# Altium schematic sheet main.SchDoc(main)
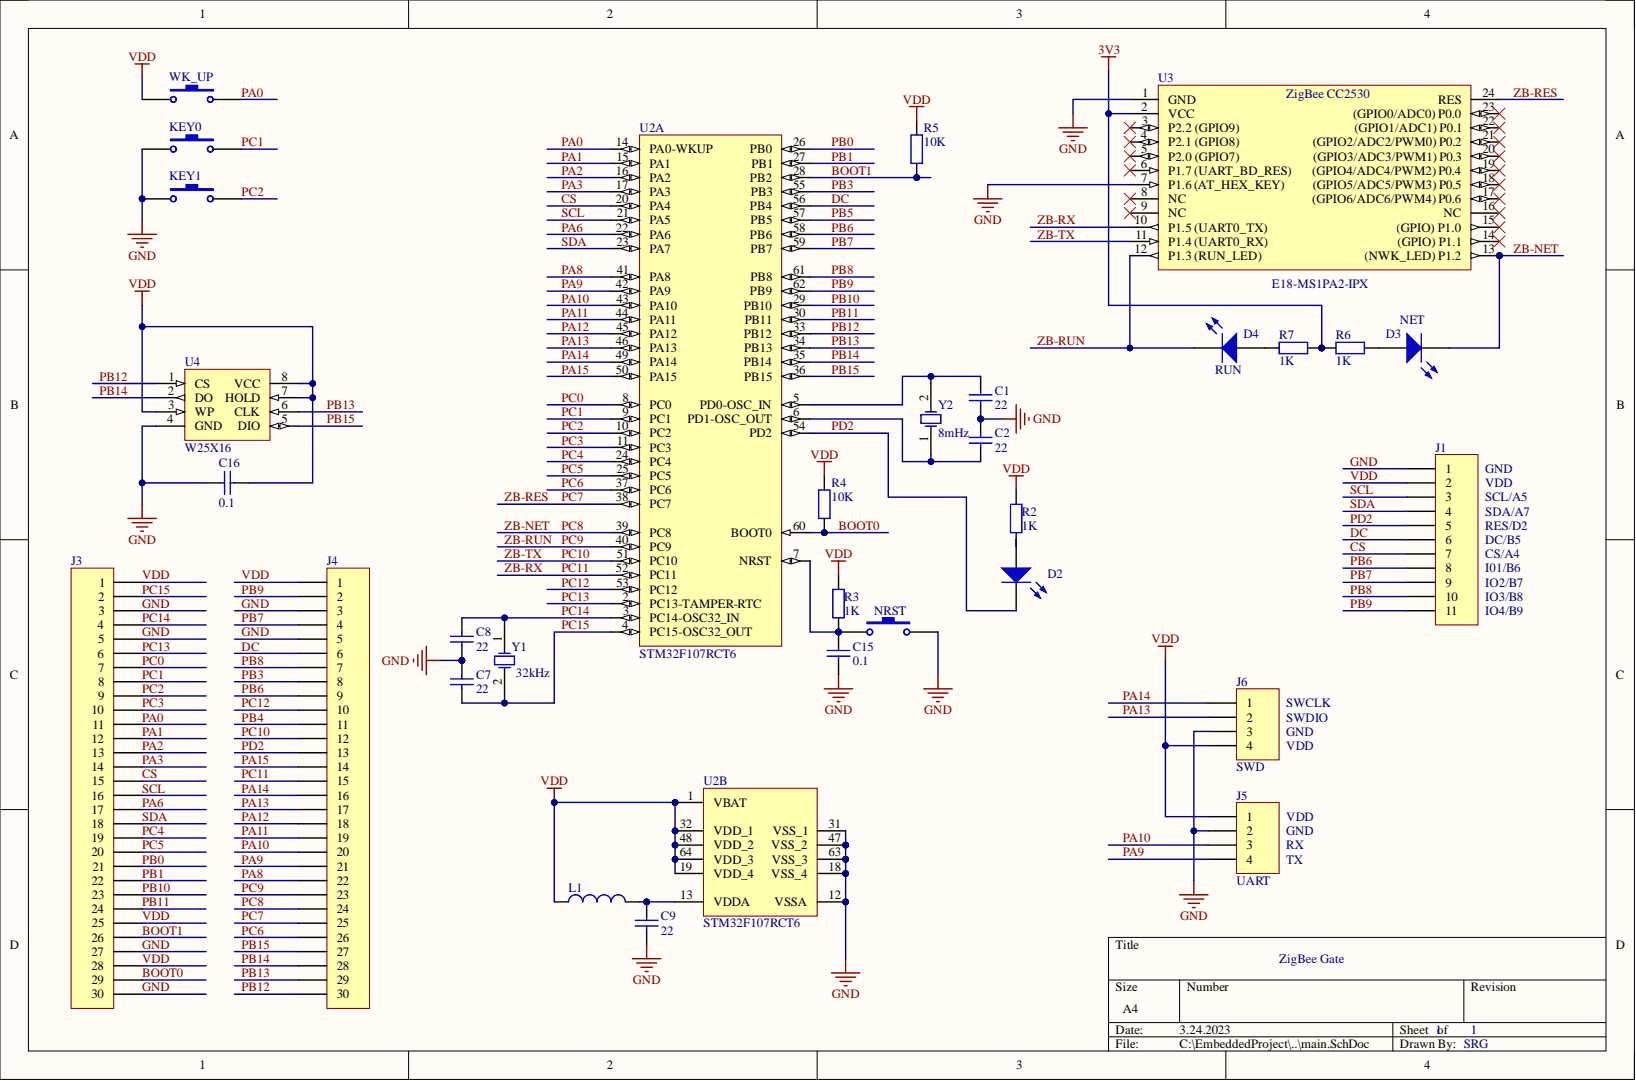
[diagram p0: main.SchDoc]

## Parts
C1  value 22  [C1206]  (hidden comment: Cap)
C2  value 22  [C1206]  (hidden comment: Cap)
C7  value 22  [C1206]  (hidden comment: Cap)
C8  value 22  [C1206]  (hidden comment: Cap)
C9  value 22  [C1206]  (hidden comment: Cap)
C15  value 0.1  [C1206]  (hidden comment: Cap)
C16  value 0.1  [C1206]  (hidden comment: Cap)
D2  BAT-LOW  [LED1206]  (value not drawn)
D3  NET  [LED1206 - BLUE]
D4  RUN  [LED1206 - GREEN]
J1  Header 11  [HDR1X11]  (value not drawn)
J3  Header 30  [HDR1X30]  (value not drawn)
J4  Header 30  [HDR1X30]  (value not drawn)
J5  UART  [HDR1X4]
J6  SWD  [HDR1X4]
KEY0  SW-PB  [SPST-2]  (value not drawn)
KEY1  SW-PB  [SPST-2]  (value not drawn)
L1  Inductor  [0402-A]  (value not drawn)
NRST  SW-PB  [SPST-2]  (value not drawn)
R2  value 1K  [R1206]  (hidden comment: Res2)
R3  value 1K  [R1206]  (hidden comment: Res2)
R4  value 10K  [R1206]  (hidden comment: Res2)
R5  value 10K  [R1206]  (hidden comment: Res2)
R6  value 1K  [R1206]  (hidden comment: Res2)
R7  value 1K  [R1206]  (hidden comment: Res2)
U2  STM32F107RCT6  [STM-LQFP64_N]  (parts A,B)
U3  E18-MS1PA2-IPX  [E18-MS1-PCB]
U4  W25X16  [8 pin SOIC_N]
WK_UP  SW-PB  [SPST-2]  (value not drawn)
Y1  32kHz  [R38]
Y2  8mHz  [R38]

## Nets
3V3: R6.1 R7.1 U3.2(VCC)
BOOT0: J3.29 R4.1 U2.60(BOOT0)
BOOT1: J3.26 R5.1 U2.28(PB2)
CS: J1.7 J3.15 U2.20(PA4)
DC: J1.6 J4.6 U2.56(PB4)
GND: C1.2 C2.1 C7.1 C8.2 C9.2 C15.2 C16.1 J1.1 J3.3 J3.5 J3.27 J3.30 J4.3 J4.5 J5.2 J6.3 KEY0.1 KEY1.1 NRST.2 U2.12(VSSA) U2.18(VSS_4) U2.31(VSS_1) U2.47(VSS_2) U2.63(VSS_3) U3.1(GND) U3.7(P1.6_(AT_HEX_KEY)) U4.4(GND)
PA0: J3.11 U2.14(PA0-WKUP) WK_UP.2
PA1: J3.12 U2.15(PA1)
PA2: J3.13 U2.16(PA2)
PA3: J3.14 U2.17(PA3)
PA6: J3.17 U2.22(PA6)
PA8: J4.22 U2.41(PA8)
PA9: J4.21 J5.4 U2.42(PA9)
PA10: J4.20 J5.3 U2.43(PA10)
PA11: J4.19 U2.44(PA11)
PA12: J4.18 U2.45(PA12)
PA13: J4.17 J6.2 U2.46(PA13)
PA14: J4.16 J6.1 U2.49(PA14)
PA15: J4.14 U2.50(PA15)
PB0: J3.21 U2.26(PB0)
PB1: J3.22 U2.27(PB1)
PB3: J4.8 U2.55(PB3)
PB4: J4.11
PB5: U2.57(PB5)
PB6: J1.8 J4.9 U2.58(PB6)
PB7: J1.9 J4.4 U2.59(PB7)
PB8: J1.10 J4.7 U2.61(PB8)
PB9: J1.11 J4.2 U2.62(PB9)
PB10: J3.23 U2.29(PB10)
PB11: J3.24 U2.30(PB11)
PB12: J4.30 U2.33(PB12) U4.1(CS)
PB13: J4.29 U2.34(PB13) U4.6(CLK)
PB14: J4.28 U2.35(PB14) U4.2(DO)
PB15: J4.27 U2.36(PB15) U4.5(DIO)
PC0: J3.7 U2.8(PC0)
PC1: J3.8 KEY0.2 U2.9(PC1)
PC2: J3.9 KEY1.2 U2.10(PC2)
PC3: J3.10 U2.11(PC3)
PC4: J3.19 U2.24(PC4)
PC5: J3.20 U2.25(PC5)
PC6: J4.26 U2.37(PC6)
PC7: J4.25 U2.38(PC7) U3.24(RES)
PC8: D3.2 J4.24 U2.39(PC8) U3.13((NWK_LED)_P1.2)
PC9: D4.2 J4.23 U2.40(PC9) U3.12(P1.3_(RUN_LED))
PC10: J4.12 U2.51(PC10) U3.11(P1.4_(UART0_RX))
PC11: J4.15 U2.52(PC11) U3.10(P1.5_(UART0_TX))
PC12: J4.10 U2.53(PC12)
PC13: J3.6 U2.2(PC13-TAMPER-RTC)
PC14: C8.1 J3.4 U2.3(PC14-OSC32_IN) Y1.1
PC15: C7.2 J3.2 U2.4(PC15-OSC32_OUT) Y1.2
PD2: D2.2 J1.5 J4.13 U2.54(PD2)
SCL: J1.3 J3.16 U2.21(PA5)
SDA: J1.4 J3.18 U2.23(PA7)
VDD: C16.2 J1.2 J3.1 J3.25 J3.28 J4.1 J5.1 J6.4 L1.1 R2.2 R3.1 R4.2 R5.2 U2.1(VBAT) U2.19(VDD_4) U2.32(VDD_1) U2.48(VDD_2) U2.64(VDD_3) U4.3(WP) U4.7(HOLD) U4.8(VCC) WK_UP.1
NetC1_1: C1.1 U2.5(PD0-OSC_IN) Y2.2
NetC2_2: C2.2 U2.6(PD1-OSC_OUT) Y2.1
NetC9_1: C9.1 L1.2 U2.13(VDDA)
NetC15_1: C15.1 NRST.1 R3.2 U2.7(NRST)
NetD2_1: D2.1 R2.1
NetD3_1: D3.1 R6.2
NetD4_1: D4.1 R7.2

Not connected: U3 3(P2.2_(GPIO9)) 4(P2.1_(GPIO8)) 5(P2.0_(GPIO7)) 6(P1.7_(UART_BD_RES)) 8(NC) 9(NC) 14((GPIO)_P1.1) 15((GPIO)_P1.0) 16(NC) 17((GPIO6/ADC6/PWM4)_P0.6) 18((GPIO5/ADC5/PWM3)_P0.5) 19((GPIO4/ADC4/PWM2)_P0.4) 20((GPIO3/ADC3/PWM1)_P0.3) 21((GPIO2/ADC2/PWM0)_P0.2) 22((GPIO1/ADC1)_P0.1) 23((GPIO0/ADC0)_P0.0)

## Library data of the parts
C1 C2 C7-C9 C15 C16 = Cap: Capacitor; Component Kind: Standard; LatestRevisionDate: 17-Jul-2002; LatestRevisionNote: Re-released for DXP Platform.; Library Name: Miscellaneous Devices.IntLib; PackageReference: RAD-0.3; Published: 8-Jun-2000; Signal Integrity: Cap; Simulation: CAP; Publisher: Altium Limited
D2 = LED0: SMD LED; value: RED; Component Kind: Standard; LatestRevisionDate: 08-Jul-2005; LatestRevisionNote: Mech Layer 1 removed.; Library Name: Miscellaneous Devices.IntLib; PackageReference: LED-0; Published: 8-Jun-2000; Simulation: LED0; Publisher: Altium Limited
D3 = LED1: SMD LED; Value: BLUE; Component Kind: Standard; LatestRevisionDate: 08-Jul-2005; LatestRevisionNote: Mech Layer 1 removed.; Library Name: Miscellaneous Devices.IntLib; PackageReference: LED-1; Published: 8-Jun-2000; Simulation: LED1; Publisher: Altium Limited
D4 = LED1: SMD LED; Value: GREEN; Component Kind: Standard; LatestRevisionDate: 08-Jul-2005; LatestRevisionNote: Mech Layer 1 removed.; Library Name: Miscellaneous Devices.IntLib; PackageReference: LED-1; Published: 8-Jun-2000; Simulation: LED1; Publisher: Altium Limited
J1 = Header 11: Header, 11-Pin; Component Kind: Standard; LatestRevisionDate: 17-Jul-2002; LatestRevisionNote: Re-released for DXP Platform.; Library Name: Miscellaneous Connectors.IntLib; Signal Integrity: Connector; Publisher: Altium Limited
J3 J4 = Header 30: Header, 30-Pin; Component Kind: Standard; LatestRevisionDate: 17-Jul-2002; LatestRevisionNote: Re-released for DXP Platform.; Library Name: Miscellaneous Connectors.IntLib; Signal Integrity: Connector; Publisher: Altium Limited
J5 J6 = Header 4: Header, 4-Pin; Component Kind: Standard; LatestRevisionDate: 17-Jul-2002; LatestRevisionNote: Re-released for DXP Platform.; Library Name: Miscellaneous Connectors.IntLib; Signal Integrity: Connector; Publisher: Altium Limited
KEY0 KEY1 NRST WK_UP = SW-PB: Switch; Component Kind: Standard; LatestRevisionDate: 17-Jul-2002; LatestRevisionNote: Re-released for DXP Platform.; Library Name: Miscellaneous Devices.IntLib; PackageDescription: Switch; 2 Leads; PackageReference: SPST-2; Published: 24-Mar-1999; Publisher: Altium Limited
L1 = Inductor: Code_IEC: 1005; Value: 10mH; Code_JEITA: 0402; Component Kind: Standard; Description: Inductor; LatestRevisionDate: 29-May-2009; LatestRevisionNote: IPC-7351 Footprint Added.; Library Name: Miscellaneous Devices.IntLib; PackageDescription: Chip Inductor; PackageReference: 0402-A; Published: 8-Jun-2000; Signal Integrity: Inductor; Simulation: INDUCTOR; Publisher: Altium Limited
R2-R5 = Res2: Resistor; Component Kind: Standard; LatestRevisionDate: 17-Jul-2002; LatestRevisionNote: Re-released for DXP Platform.; Library Name: Miscellaneous Devices.IntLib; PackageDescription: Axial Device, Thru-Hole; 2 Leads; 0.4 in Pin Spacing; PackageReference: AXIAL-0.4; Published: 8-Jun-2000; Signal Integrity: Res; Simulation: RESISTOR; Publisher: Altium Limited
R6 R7 = Res2: SMD 1206 Resistor Body 3.2 x 1.6 mm; Component Kind: Standard; LatestRevisionDate: 17-Jul-2002; LatestRevisionNote: Re-released for DXP Platform.; Library Name: Miscellaneous Devices.IntLib; PackageReference: AXIAL-0.4; Published: 8-Jun-2000; Signal Integrity: Res; Simulation: RESISTOR; Publisher: Altium Limited
U2 = STM32F107RCT6: ARM Cortex-M3 32-bit MCU, 256 KB Flash, 64 KB Internal RAM, 51 I/Os, 64-pin LQFP, -40 to 85 degC, Tray; A/D Converter: 2x12-bit (16 Channel); D/A Converter: 2x12-bit (2 Channel); FLASH Size (Prog) (kB): 256; I/Os (High Current): 51; Internal RAM Size (kB): 64; Operating Frequency(F) (Processor speed) (MHz): 72; Supply Voltage(Vcc) min (V): 2; Component Kind: Standard; ComponentLink1Description: Manufacturer URL; ComponentLink1URL: http://www.st.com/web/en/home.html; ComponentLink2Description: Datasheet; ComponentLink2URL: http://www.st.com/st-web-ui/static/active/en/resource/technical/document/datasheet/CD00220364.pdf; ComponentLink3Description: Package Specification; ComponentLink3URL: http://www.st.com/st-web-ui/static/active/en/resource/technical/document/datasheet/DM00037051.pdf; Core: ARM Cortex-M3; DatasheetVersion: Rev. 6; Library Name: STMicroelectronics STM32 F1.IntLib; Mounting Technology: Surface Mount; PackageDescription: 64-Pin Low Profile Quad Flat Package, 10 x 10 mm Body, 0.5 mm Pitch; PackageReference: LQFP64; PackageVersion: Rev. 4, 06/2013; Packing: Tray; PartNumber: STM32F107RCT6; Supplier 1: Digi-Key; Supplier 2: Farnell; Supplier 3: Newark; Supplier 4: Newark; Supplier 5: Mouser; Supplier Part Number 1: 497-8914-ND; Supplier Part Number 2: 1737139; Supplier Part Number 3: 26R3019; Supplier Part Number 4: 25R9444; Supplier Part Number 5: 511-STM32F107RCT6
U3 = E18-MS1-PCB: Zigbee IO CC2530 2,4 ГГц 2,5 МВт; Component Kind: Standard; Library Name: Schlib1.SchLib
U4 = W25X16: SPI Flash; Component Kind: Standard; Library Name: Schlib1.SchLib
Y1 Y2 = XTAL: Crystal Oscillator; Component Kind: Standard; LatestRevisionDate: 17-Jul-2002; LatestRevisionNote: Re-released for DXP Platform.; Library Name: Miscellaneous Devices.IntLib; PackageDescription: Crystal, Thru-Hole; 2 Leads; Body 3.1 x 8.2 mm (Dia.xH); PackageReference: R38; Published: 8-Jun-2000; Simulation: XTAL; Publisher: Altium Limited
